# Altium schematic sheet biv2-batb_1a.SchDoc
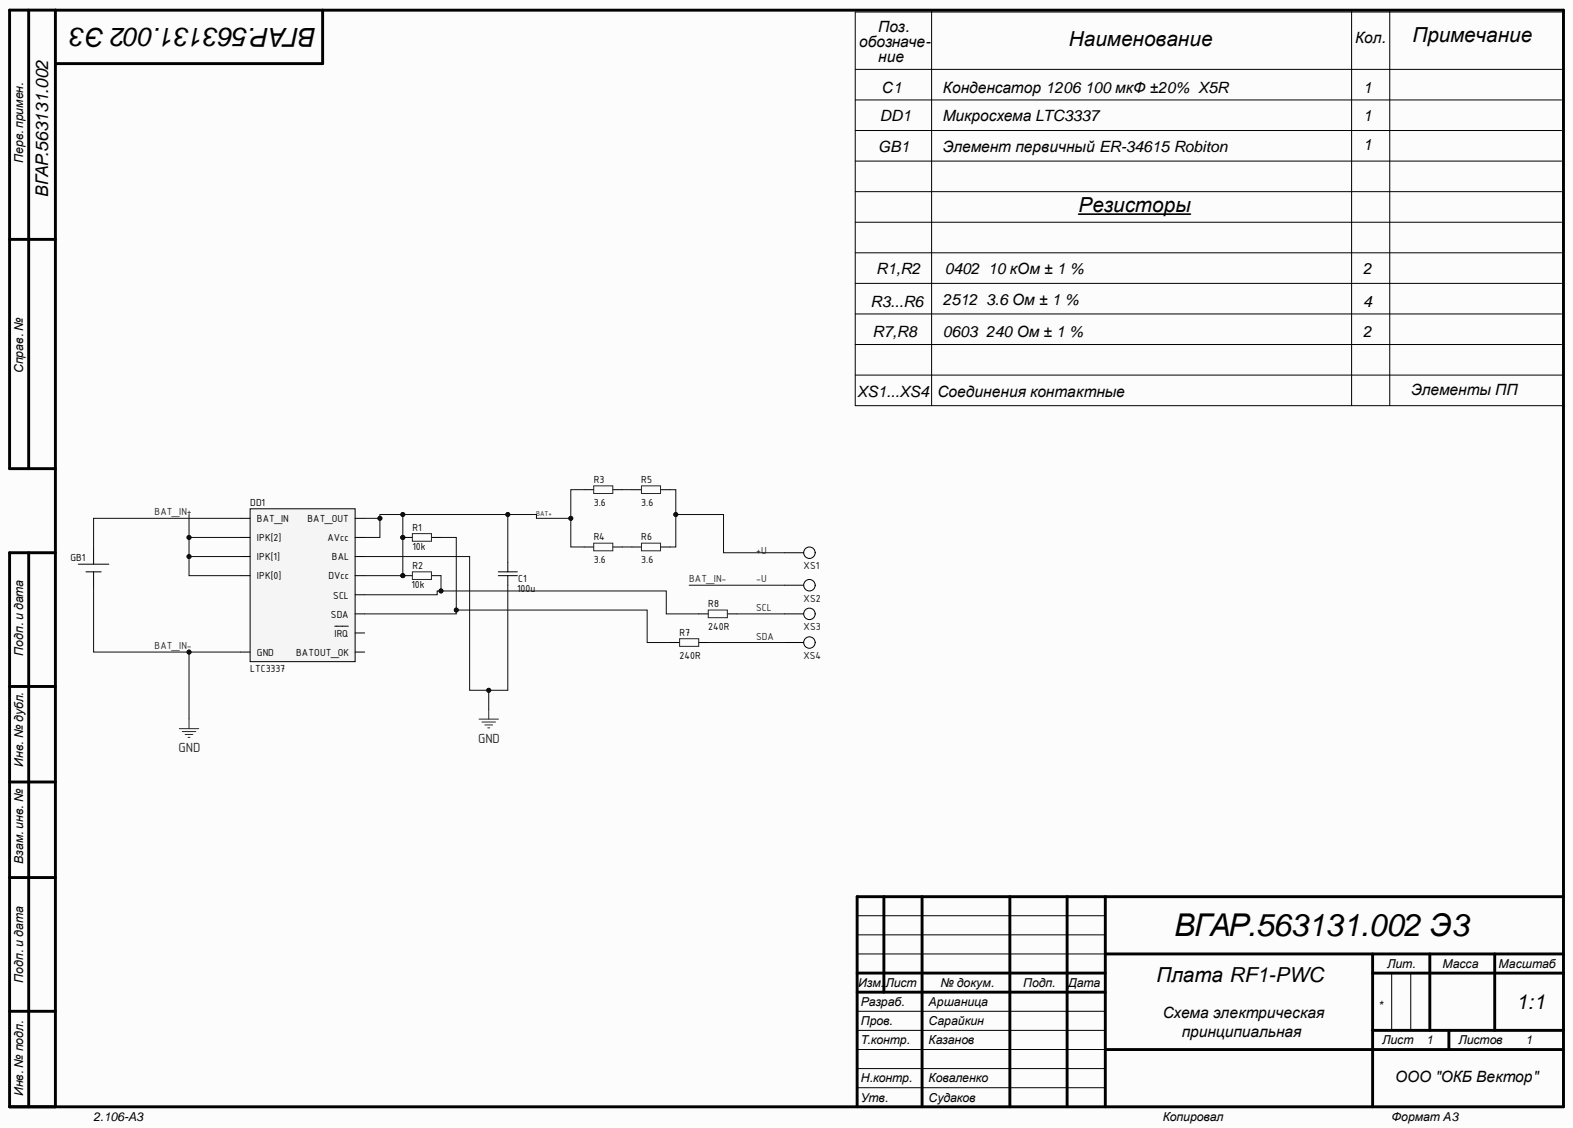
[diagram p0: biv2-batb_1a.SchDoc]

## Parts
C1  value 100u  [CAP: 1206]  (hidden comment: Ceramic capacitor, 100u, X7R, ±10%, 6V, 1206, -55...+125°C)
DD1  LTC3337  [12-LFCSP]
R1  value 10k  [RES: 0402]  (hidden comment: Resistor film, 10k, , 0402, 0.063W, -55...+125°C)
R2  value 10k  [RES: 0402]  (hidden comment: Resistor film, 10k, , 0402, 0.063W, -55...+125°C)
R3  value 3.6  [RES: 2512]  (hidden comment: Resistor film, 3.6, ±5%, 2512, 1W, -55...+125°C)
R4  value 3.6  [RES: 2512]  (hidden comment: Resistor film, 3.6, ±5%, 2512, 1W, -55...+125°C)
R5  value 3.6  [RES: 2512]  (hidden comment: Resistor film, 3.6, ±5%, 2512, 1W, -55...+125°C)
R6  value 3.6  [RES: 2512]  (hidden comment: Resistor film, 3.6, ±5%, 2512, 1W, -55...+125°C)
R7  value 240R  [RES: 0603]  (hidden comment: Resistor film, 240R, ±5%, 0603, 0.1W, -55...+125°C)
R8  value 240R  [RES: 0603]  (hidden comment: Resistor film, 240R, ±5%, 0603, 0.1W, -55...+125°C)
XS1  Testpoint_HS1mm  [TestPoint(without 3D)]  (value not drawn)
XS2  Testpoint_HS1mm  [TestPoint(without 3D)]  (value not drawn)
XS3  Testpoint_HS1mm  [TestPoint(without 3D)]  (value not drawn)
XS4  Testpoint_HS1mm  [TestPoint(without 3D)]  (value not drawn)

## Nets
-U: C1.1 DD1.1(BAL) DD1.13(GND) XS2.1
BAT+: C1.2 DD1.2(AVcc) DD1.3(DVcc) DD1.12(BAT_OUT) R1.1 R2.1 R3.1 R4.1
BAT_IN+: DD1.11(BAT_IN)
SCL: R8.2 XS3.1
SDA: R7.2 XS4.1
NetDD1_4: DD1.4(SCL) R2.2 R8.1
NetDD1_5: DD1.5(SDA) R1.2 R7.1
NetDD1_8: DD1.8(IPK[2]) DD1.9(IPK[1]) DD1.10(IPK[0])
NetR3_2: R3.2 R5.1
NetR4_2: R4.2 R6.1
NetR5_2: R5.2 R6.2 XS1.1
+U:

Not connected: DD1 6(IRQ) 7(BATOUT_OK)

## Library data of the parts
C1 = Ceramic_1206: Manufacturer: Yageo; PartNumber: CC1206KRX7R9BB104; Component Kind: Standard; HelpURL: https://www.digikey.com/product-detail/en/yageo/CC1206KRX7R9BB104/311-1179-1-ND/303089; Library Name: capacitor.IntLib; Package: 1206; Temperature: -55...+125°C; Tolerance: ±10%; TypeCapacitor: Ceramic capacitor; TypeDielectric: X7R; ValueManufacturerPartNumber: Ceramic capacitor, 100u, X7R, ±10%, 6V, 1206, -55...+125°C; Voltage: 6V
DD1 = LTC3337: Battery SOH monitor; Component Kind: Standard; ComponentLink1Description: Datasheet; ComponentLink1URL: https://www.analog.com/en/products/ltc3337.html; Library Name: ic-sensor.IntLib
R1 R2 = Resistor_0402: Manufacturer: Yageo; PartNumber: RC0402JR-07100RL; Component Kind: Standard; HelpURL: https://www.digikey.com/product-detail/en/yageo/RC0402JR-07100RL/311-100JRCT-ND/729362; Library Name: resistor.IntLib; Package: 0402; Power: 0.063W; Temperature: -55...+125°C; TypeResistor: Resistor film; ValueManufacturerPartNumber: Resistor film, 10k, , 0402, 0.063W, -55...+125°C
R3-R6 = Resistor_2512: Manufacturer: Yageo; PartNumber: RC2512JK-07100RL; Component Kind: Standard; HelpURL: https://www.digikey.com/product-detail/en/yageo/RC2512JK-07100RL/YAG3393CT-ND/5962215; Library Name: resistor.IntLib; Package: 2512; Power: 1W; Temperature: -55...+125°C; Tolerance: ±5%; TypeResistor: Resistor film; ValueManufacturerPartNumber: Resistor film, 3.6, ±5%, 2512, 1W, -55...+125°C
R7 R8 = Resistor_0603: Manufacturer: Yageo; PartNumber: RC0603JR-07100RL; Component Kind: Standard; HelpURL: https://www.digikey.com/product-detail/en/yageo/RC0603JR-07100RL/311-100GRCT-ND/729644; Library Name: resistor.IntLib; Package: 0603; Power: 0.1W; Temperature: -55...+125°C; Tolerance: ±5%; TypeResistor: Resistor film; ValueManufacturerPartNumber: Resistor film, 240R, ±5%, 0603, 0.1W, -55...+125°C
XS1-XS4 = Testpoint_HS1mm: Component Kind: Standard; Library Name: connector.IntLib
